# Altium schematic sheet di.SchDoc(di)
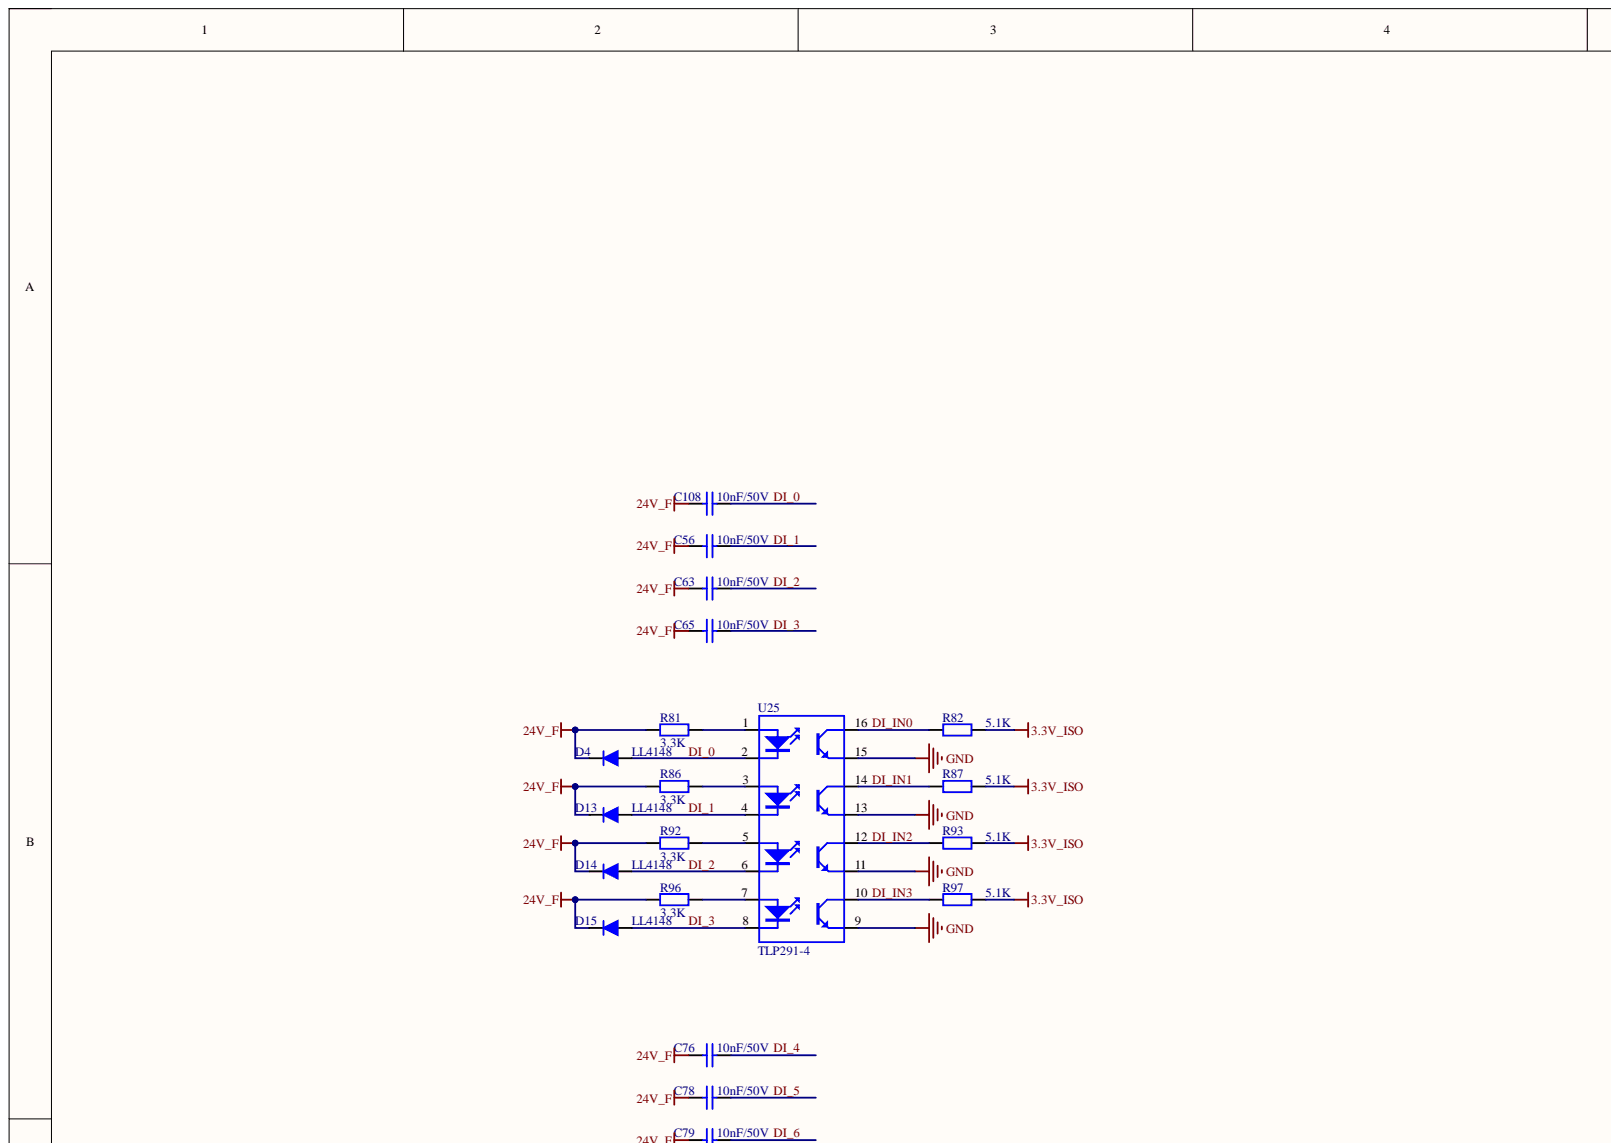
[diagram p0: di.SchDoc, top left]
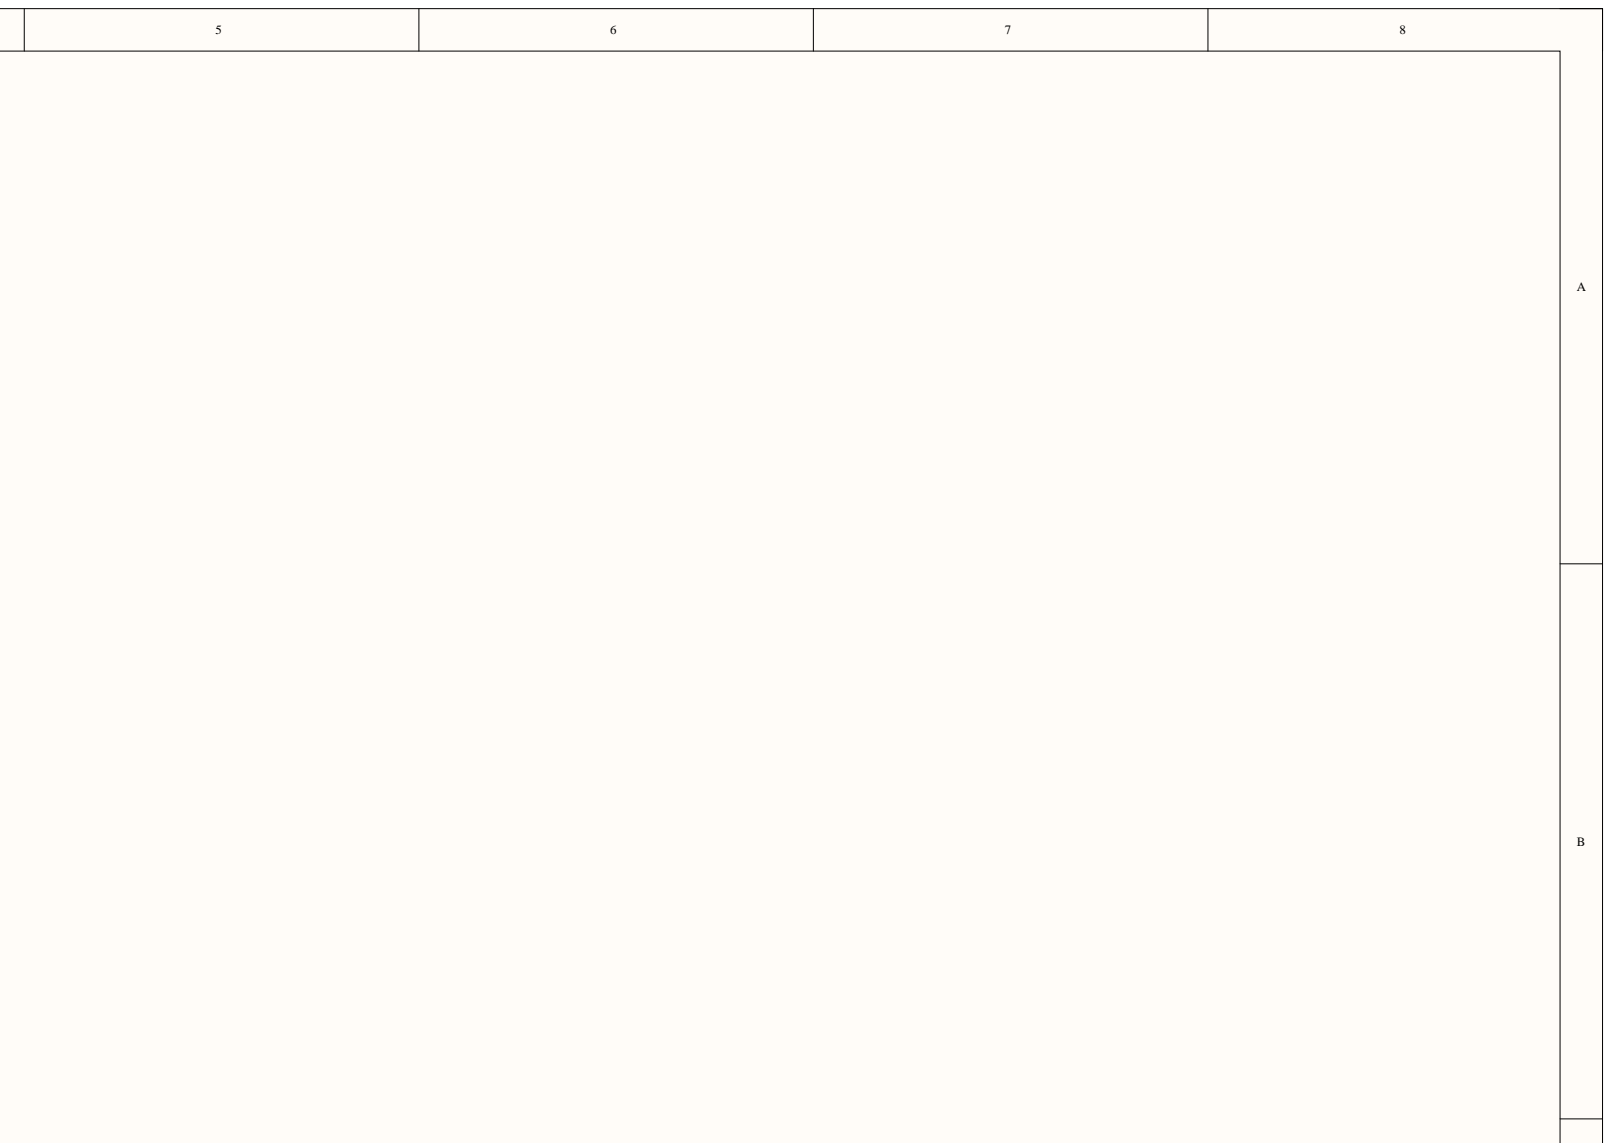
[diagram p1: di.SchDoc, top right]
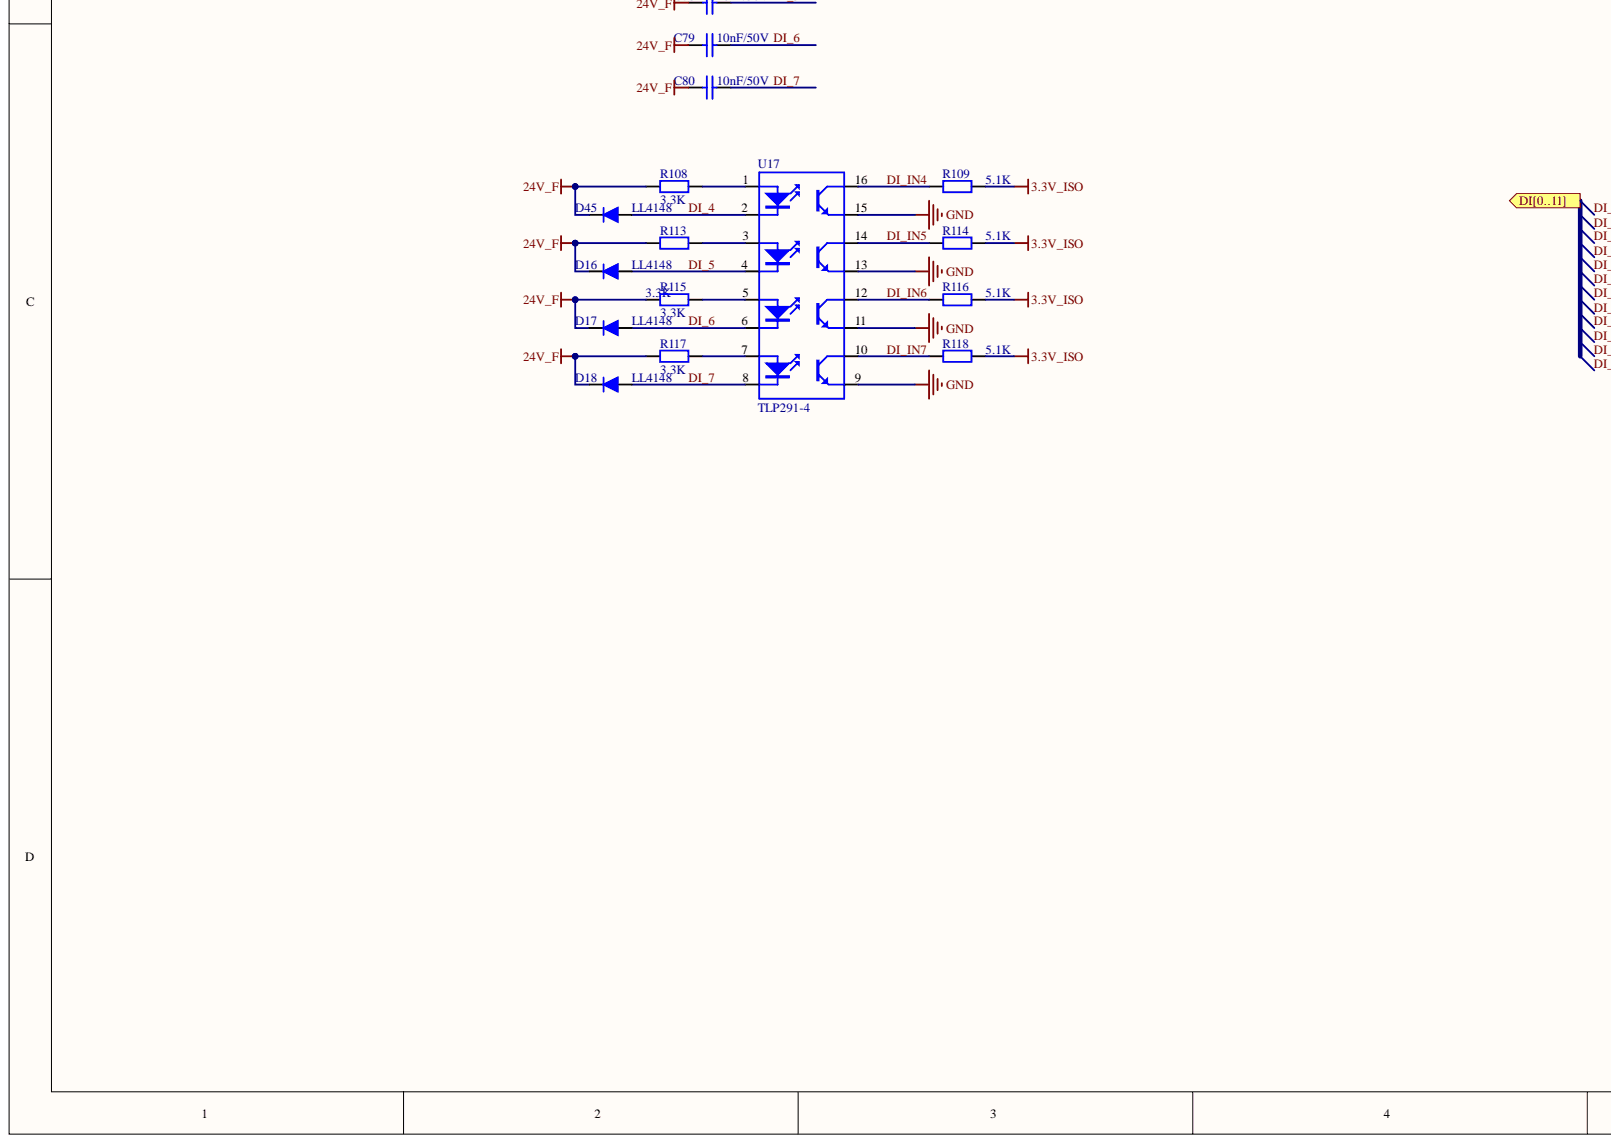
[diagram p2: di.SchDoc, bottom left]
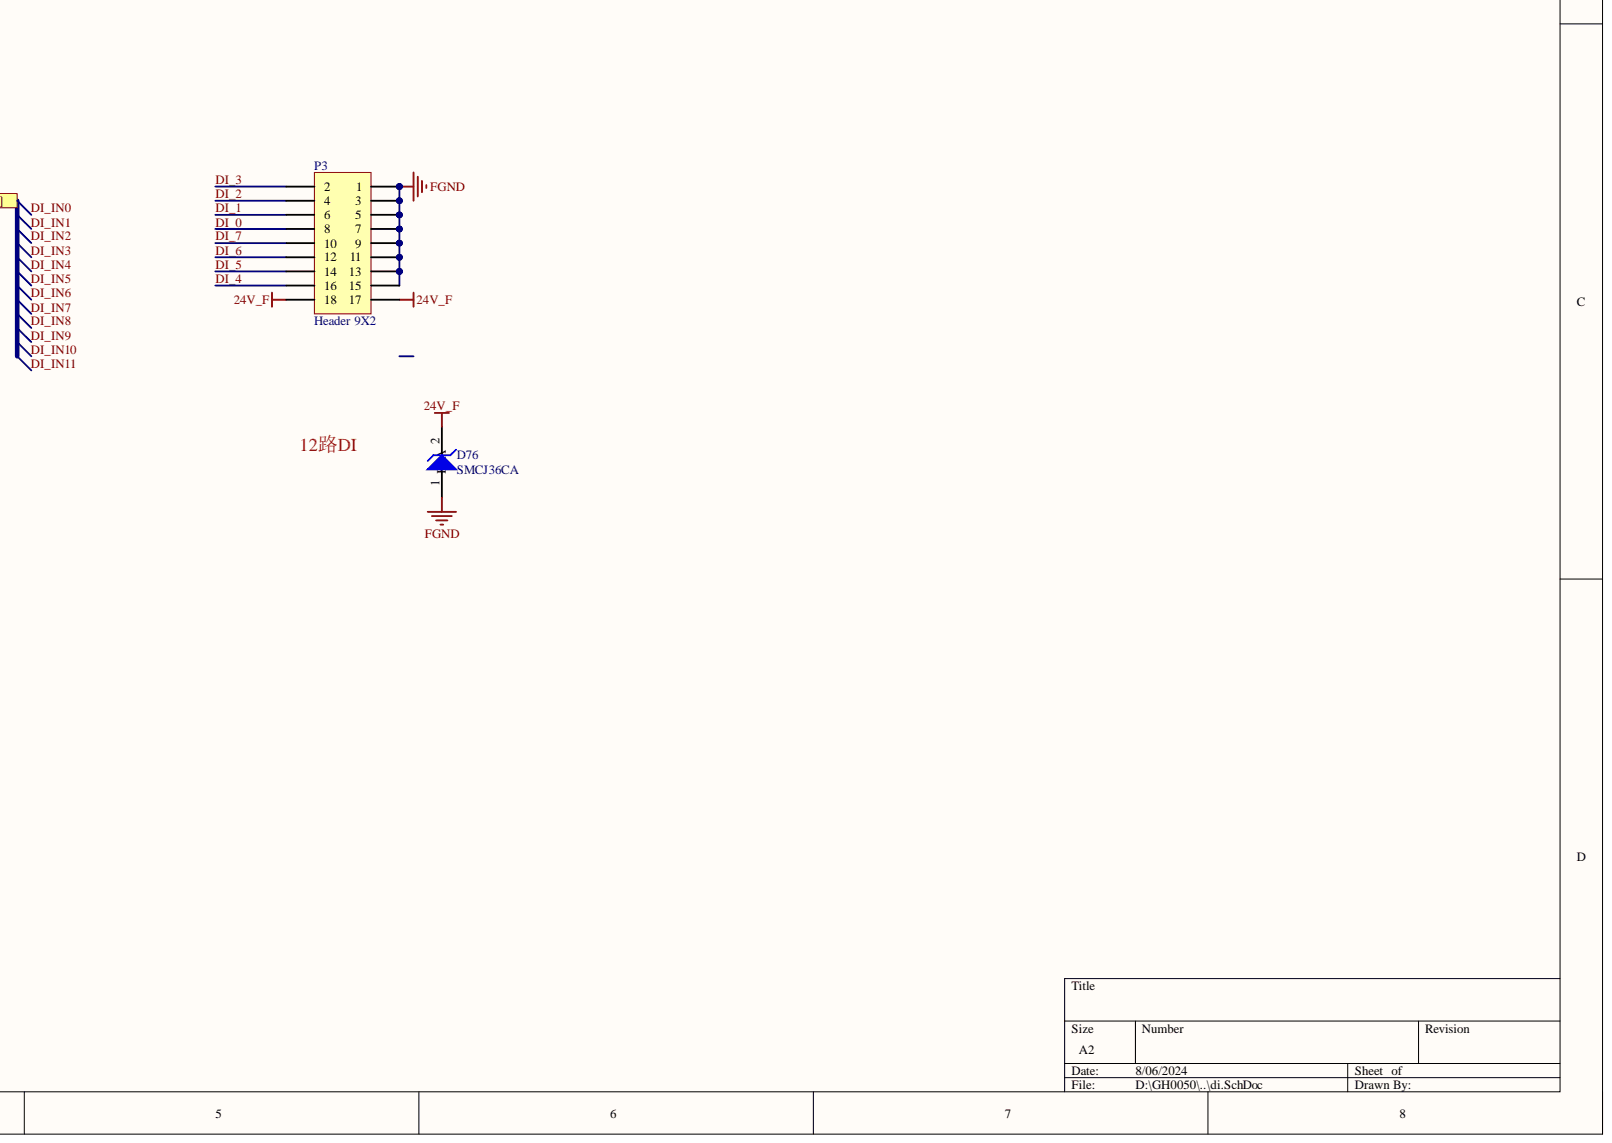
[diagram p3: di.SchDoc, bottom right]

## Parts
C56  10nF/50V  [0603C]
C63  10nF/50V  [0603C]
C65  10nF/50V  [0603C]
C76  10nF/50V  [0603C]
C78  10nF/50V  [0603C]
C79  10nF/50V  [0603C]
C80  10nF/50V  [0603C]
C108  10nF/50V  [0603C]
D4  LL4148  [DO-213AB/LL34/MiniMELF]
D13  LL4148  [DO-213AB/LL34/MiniMELF]
D14  LL4148  [DO-213AB/LL34/MiniMELF]
D15  LL4148  [DO-213AB/LL34/MiniMELF]
D16  LL4148  [DO-213AB/LL34/MiniMELF]
D17  LL4148  [DO-213AB/LL34/MiniMELF]
D18  LL4148  [DO-213AB/LL34/MiniMELF]
D45  LL4148  [DO-213AB/LL34/MiniMELF]
D76  SMCJ36CA  [smc]
P3  Header 9X2  [XH2.54_2x9]
R81  3.3K  [0603R]
R82  5.1K  [0603R]
R86  3.3K  [0603R]
R87  5.1K  [0603R]
R92  3.3K  [0603R]
R93  5.1K  [0603R]
R96  3.3K  [0603R]
R97  5.1K  [0603R]
R108  3.3K  [0603R]
R109  5.1K  [0603R]
R113  3.3K  [0603R]
R114  5.1K  [0603R]
R115  3.3K  [0603R]
R116  5.1K  [0603R]
R117  3.3K  [0603R]
R118  5.1K  [0603R]
U17  TLP291-4  [SOP16-T]
U25  TLP291-4  [SOP16-T]

## Ports
DI0, DI1, DI2, DI3, DI4, DI5, DI6, DI7, DI8, DI9, DI10, DI11, DI[0..11]

## Nets
3.3V_ISO: R82.1 R87.1 R93.1 R97.1 R109.1 R114.1 R116.1 R118.1
24V_F: C56.1 C63.1 C65.1 C76.1 C78.1 C79.1 C80.1 C108.1 D4.2 D13.2 D14.2 D15.2 D16.2 D17.2 D18.2 D45.2 D76.2 P3.17 P3.18 R81.2 R86.2 R92.2 R96.2 R108.2 R113.2 R115.2 R117.2
FGND: D76.1 P3.1 P3.3 P3.5 P3.7 P3.9 P3.11 P3.13 P3.15
GND: U17.9 U17.11 U17.13 U17.15 U25.9 U25.11 U25.13 U25.15
DI_0: C108.2 D4.1 P3.8 U25.2
DI_1: C56.2 D13.1 P3.6 U25.4
DI_2: C63.2 D14.1 P3.4 U25.6
DI_3: C65.2 D15.1 P3.2 U25.8
DI_4: C76.2 D45.1 P3.16 U17.2
DI_5: C78.2 D16.1 P3.14 U17.4
DI_6: C79.2 D17.1 P3.12 U17.6
DI_7: C80.2 D18.1 P3.10 U17.8
DI_IN0: R82.2 U25.16
DI_IN1: R87.2 U25.14
DI_IN2: R93.2 U25.12
DI_IN3: R97.2 U25.10
DI_IN4: R109.2 U17.16
DI_IN5: R114.2 U17.14
DI_IN6: R116.2 U17.12
DI_IN7: R118.2 U17.10
NetR81_1: R81.1 U25.1
NetR86_1: R86.1 U25.3
NetR92_1: R92.1 U25.5
NetR96_1: R96.1 U25.7
NetR108_1: R108.1 U17.1
NetR113_1: R113.1 U17.3
NetR115_1: R115.1 U17.5
NetR117_1: R117.1 U17.7
DI_IN8:
DI_IN9:
DI_IN10:
DI_IN11:
N00001_0: ; port DI[0..11]
N00001_1: ; port DI[0..11]
N00001_2: ; port DI[0..11]
N00001_3: ; port DI[0..11]
N00001_4: ; port DI[0..11]
N00001_5: ; port DI[0..11]
N00001_6: ; port DI[0..11]
N00001_7: ; port DI[0..11]
N00001_8: ; port DI[0..11]
N00001_9: ; port DI[0..11]
N00001_10: ; port DI[0..11]
N00001_11: ; port DI[0..11]

## Library data of the parts
C56 C63 C65 C76 C78-C80 C108 = Cap: Capacitor; Value: 22nF; Component Kind: Standard; LatestRevisionDate: 17-Jul-2002; LatestRevisionNote: Re-released for DXP Platform.; Library Name: Miscellaneous Devices.IntLib; PackageDescription: Radial Cap, Thru-Hole; 2 Leads; 0.3 in Pin Spacing; PackageReference: RAD-0.3; Published: 8-Jun-2000; Signal Integrity: Cap; Simulation: CAP; Publisher: Altium Limited
D4 D13-D18 D45 = LL4148: VISHAY,LL4148,圆柱体MiniMELF100V/2A,ROHS; 名称: 快速开关二极管; 版本: V1.00; 物料编号: APLLY; Component Kind: Standard; Library Name: Device2018.IntLib; 制造商: VISHAY
D76 = D Zener: SMBJ36CA; Component Kind: Standard; LatestRevisionDate: 17-Jul-2002; LatestRevisionNote: Re-released for DXP Platform.; Library Name: Miscellaneous Devices.IntLib; PackageReference: DIODE-0.7; Published: 8-Jun-2000; Signal Integrity: D Zener; Simulation: ZENER; Publisher: Altium Limited
P3 = Header 9X2: Header, 9-Pin, Dual row; Component Kind: Standard; LatestRevisionDate: 17-Jul-2002; LatestRevisionNote: Re-released for DXP Platform.; Library Name: Miscellaneous Connectors.IntLib; Signal Integrity: Connector; Publisher: Altium Limited
R81 R82 R86 R87 R92 R93 R96 R97 R108 R109 R113-R118 = Res0805M: Component Kind: Standard; Library Name: MQlibrary.IntLib
U17 U25 = TLP281-4: Component Kind: Standard; Library Name: DI16.SchLib
